# Altium schematic sheet Output_1.SchDoc(Output_1)
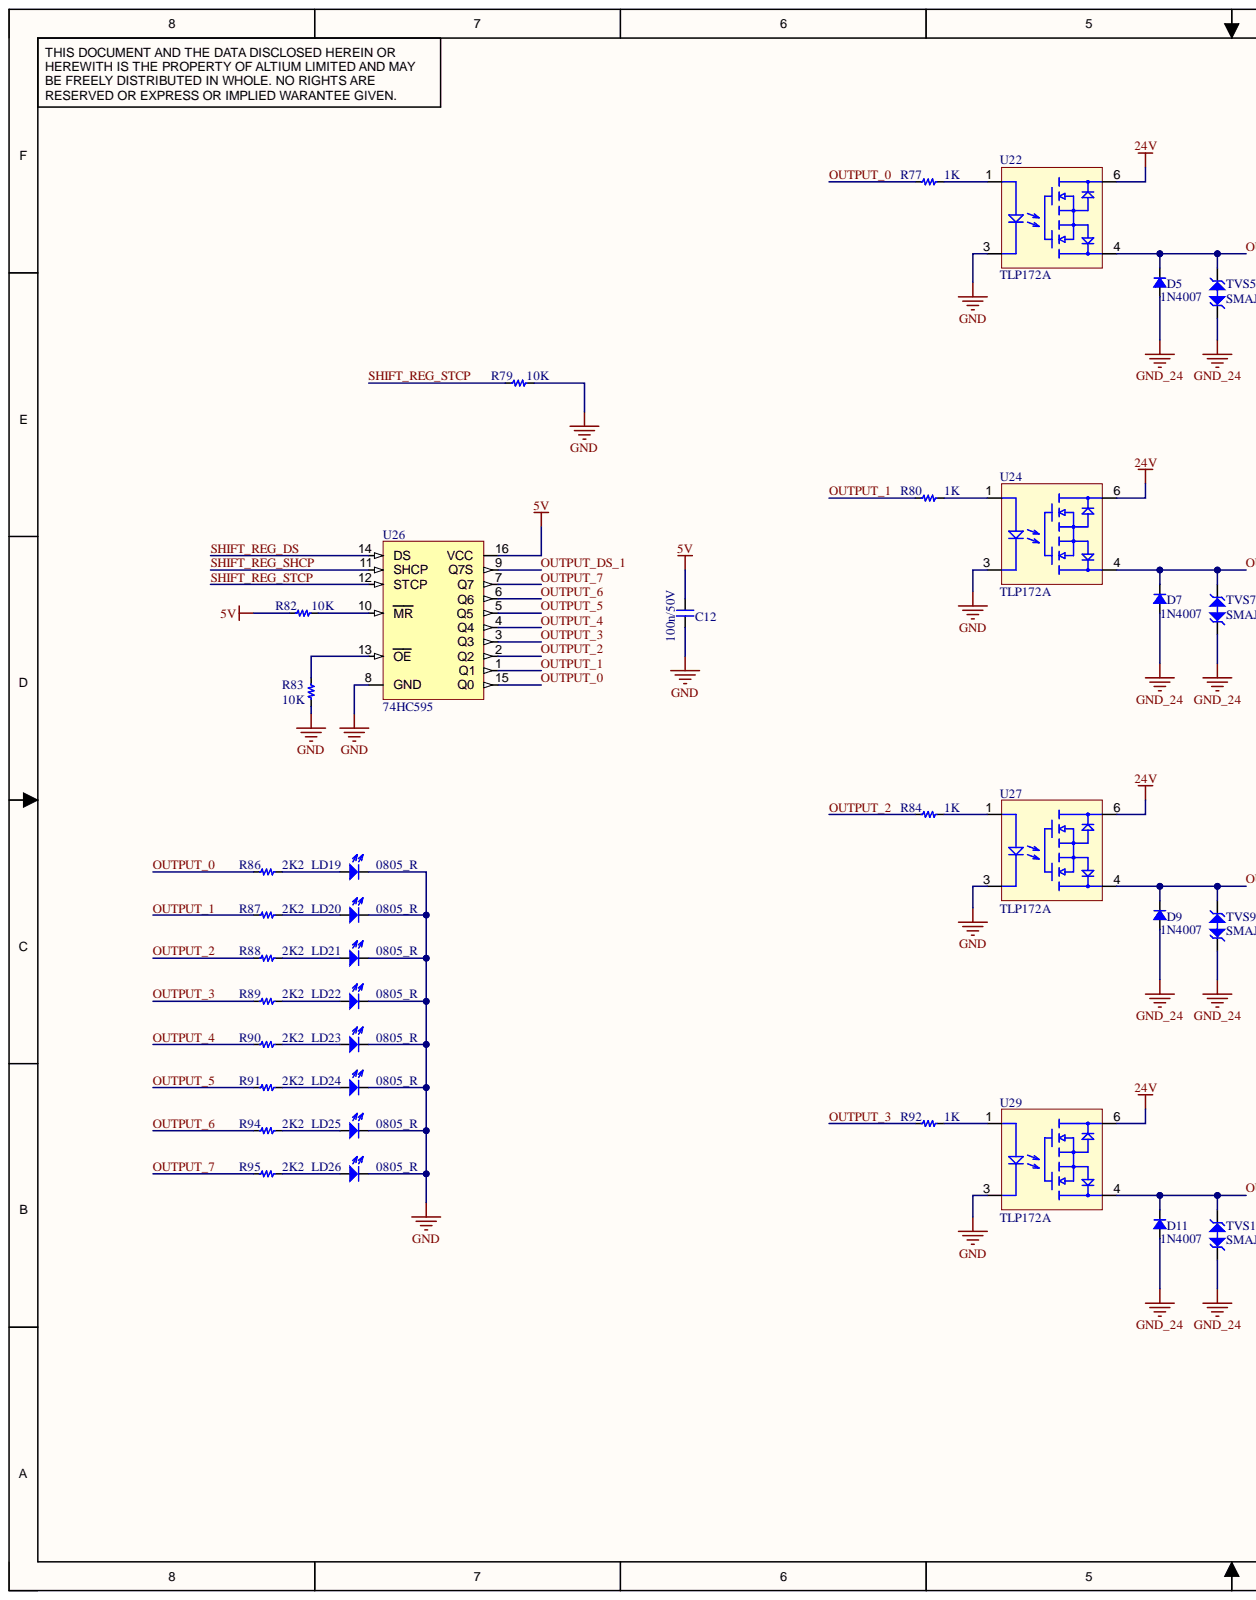
[diagram p0: Output_1.SchDoc, left]
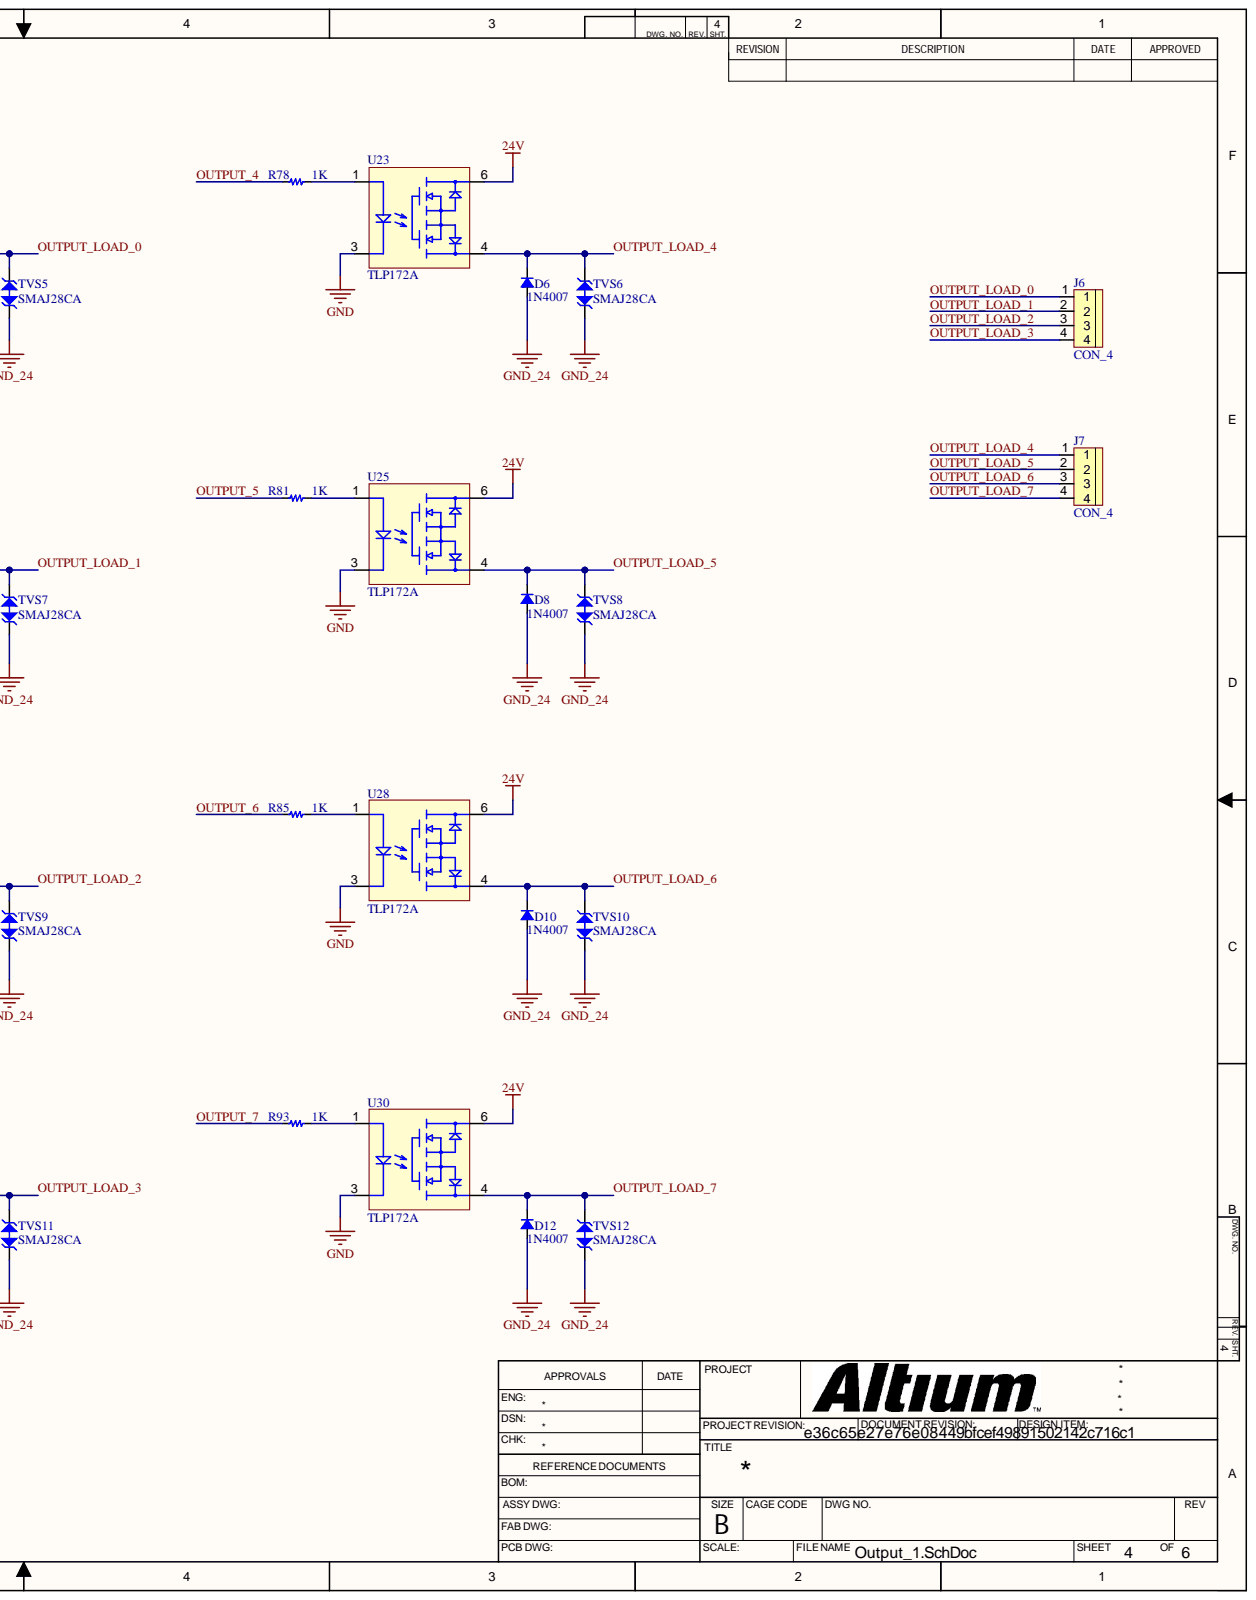
[diagram p1: Output_1.SchDoc, right]

## Parts
C12  100n/50V  [C-0805]
D5  1N4007  [SMA]
D6  1N4007  [SMA]
D7  1N4007  [SMA]
D8  1N4007  [SMA]
D9  1N4007  [SMA]
D10  1N4007  [SMA]
D11  1N4007  [SMA]
D12  1N4007  [SMA]
J6  CON_4  [KF-5.0-4P]
J7  CON_4  [KF-5.0-4P]
LD19  0805_R  [LED_0805_R]
LD20  0805_R  [LED_0805_R]
LD21  0805_R  [LED_0805_R]
LD22  0805_R  [LED_0805_R]
LD23  0805_R  [LED_0805_R]
LD24  0805_R  [LED_0805_R]
LD25  0805_R  [LED_0805_R]
LD26  0805_R  [LED_0805_R]
R77  1K  [R-0805]
R78  1K  [R-0805]
R79  10K  [R-0805]
R80  1K  [R-0805]
R81  1K  [R-0805]
R82  10K  [R-0805]
R83  10K  [R-0805]
R84  1K  [R-0805]
R85  1K  [R-0805]
R86  2K2  [R-0805]
R87  2K2  [R-0805]
R88  2K2  [R-0805]
R89  2K2  [R-0805]
R90  2K2  [R-0805]
R91  2K2  [R-0805]
R92  1K  [R-0805]
R93  1K  [R-0805]
R94  2K2  [R-0805]
R95  2K2  [R-0805]
TVS5  SMAJ28CA  [TVS_BIDI]
TVS6  SMAJ28CA  [TVS_BIDI]
TVS7  SMAJ28CA  [TVS_BIDI]
TVS8  SMAJ28CA  [TVS_BIDI]
TVS9  SMAJ28CA  [TVS_BIDI]
TVS10  SMAJ28CA  [TVS_BIDI]
TVS11  SMAJ28CA  [TVS_BIDI]
TVS12  SMAJ28CA  [TVS_BIDI]
U22  TLP172A  [SO - 4]
U23  TLP172A  [SO - 4]
U24  TLP172A  [SO - 4]
U25  TLP172A  [SO - 4]
U26  74HC595  [SOIC-16-D]
U27  TLP172A  [SO - 4]
U28  TLP172A  [SO - 4]
U29  TLP172A  [SO - 4]
U30  TLP172A  [SO - 4]

## Nets
5V: C12.2 R82.2 U26.16(VCC)
24V: U22.6 U23.6 U24.6 U25.6 U27.6 U28.6 U29.6 U30.6
GND: C12.1 LD19.2 LD20.2 LD21.2 LD22.2 LD23.2 LD24.2 LD25.2 LD26.2 R79.1 R83.1 U22.3 U23.3 U24.3 U25.3 U26.8(GND) U27.3 U28.3 U29.3 U30.3
GND_24: D5.1 D6.1 D7.1 D8.1 D9.1 D10.1 D11.1 D12.1 TVS5.1 TVS6.1 TVS7.1 TVS8.1 TVS9.1 TVS10.1 TVS11.1 TVS12.1
OUTPUT_0: R77.2 R86.2 U26.15(Q0)
OUTPUT_1: R80.2 R87.2 U26.1(Q1)
OUTPUT_2: R84.2 R88.2 U26.2(Q2)
OUTPUT_3: R89.2 R92.2 U26.3(Q3)
OUTPUT_4: R78.2 R90.2 U26.4(Q4)
OUTPUT_5: R81.2 R91.2 U26.5(Q5)
OUTPUT_6: R85.2 R94.2 U26.6(Q6)
OUTPUT_7: R93.2 R95.2 U26.7(Q7)
OUTPUT_DS_1: U26.9(Q7S)
OUTPUT_LOAD_0: D5.2 J6.1 TVS5.2 U22.4
OUTPUT_LOAD_1: D7.2 J6.2 TVS7.2 U24.4
OUTPUT_LOAD_2: D9.2 J6.3 TVS9.2 U27.4
OUTPUT_LOAD_3: D11.2 J6.4 TVS11.2 U29.4
OUTPUT_LOAD_4: D6.2 J7.1 TVS6.2 U23.4
OUTPUT_LOAD_5: D8.2 J7.2 TVS8.2 U25.4
OUTPUT_LOAD_6: D10.2 J7.3 TVS10.2 U28.4
OUTPUT_LOAD_7: D12.2 J7.4 TVS12.2 U30.4
SHIFT_REG_DS: U26.14(DS)
SHIFT_REG_SHCP: U26.11(SHCP)
SHIFT_REG_STCP: R79.2 U26.12(STCP)
NetLD19_1: LD19.1 R86.1
NetLD20_1: LD20.1 R87.1
NetLD21_1: LD21.1 R88.1
NetLD22_1: LD22.1 R89.1
NetLD23_1: LD23.1 R90.1
NetLD24_1: LD24.1 R91.1
NetLD25_1: LD25.1 R94.1
NetLD26_1: LD26.1 R95.1
NetR77_1: R77.1 U22.1
NetR78_1: R78.1 U23.1
NetR80_1: R80.1 U24.1
NetR81_1: R81.1 U25.1
NetR82_1: R82.1 U26.10(MR)
NetR83_2: R83.2 U26.13(OE)
NetR84_1: R84.1 U27.1
NetR85_1: R85.1 U28.1
NetR92_1: R92.1 U29.1
NetR93_1: R93.1 U30.1

## Library data of the parts
C12 = CERAMIC_CAP: Component Kind: Standard; Library Name: NXVu.SchLib
D5-D12 = DIODE: Component Kind: Standard; Library Name: NXVu.SchLib
LD19-LD26 = LED_0805_R: Component Kind: Standard; Library Name: NXVu.SchLib
R77-R95 = RESISTOR: Component Kind: Standard; Library Name: NXVu.SchLib
TVS5-TVS12 = TVS_Bidirection: Component Kind: Standard; Library Name: NXVu.SchLib
U22-U25 U27-U30 = TLP172A: Solid State SPST-NO(1 Form A) SOP-4-2.54mm; Component Kind: Standard; Library Name: NXVu.SchLib
U26 = 74HC595: 2V~6V 1 19ns@4.5V,50pF Serial-to-Serial or Parallel SOIC-16 Shift Registers RoHS; Component Kind: Standard; Library Name: NXVu.SchLib
J6 J7 = CON_4: Component Kind: Standard; Library Name: NXVu.SchLib
